# Altium schematic sheet POWER.SchDoc
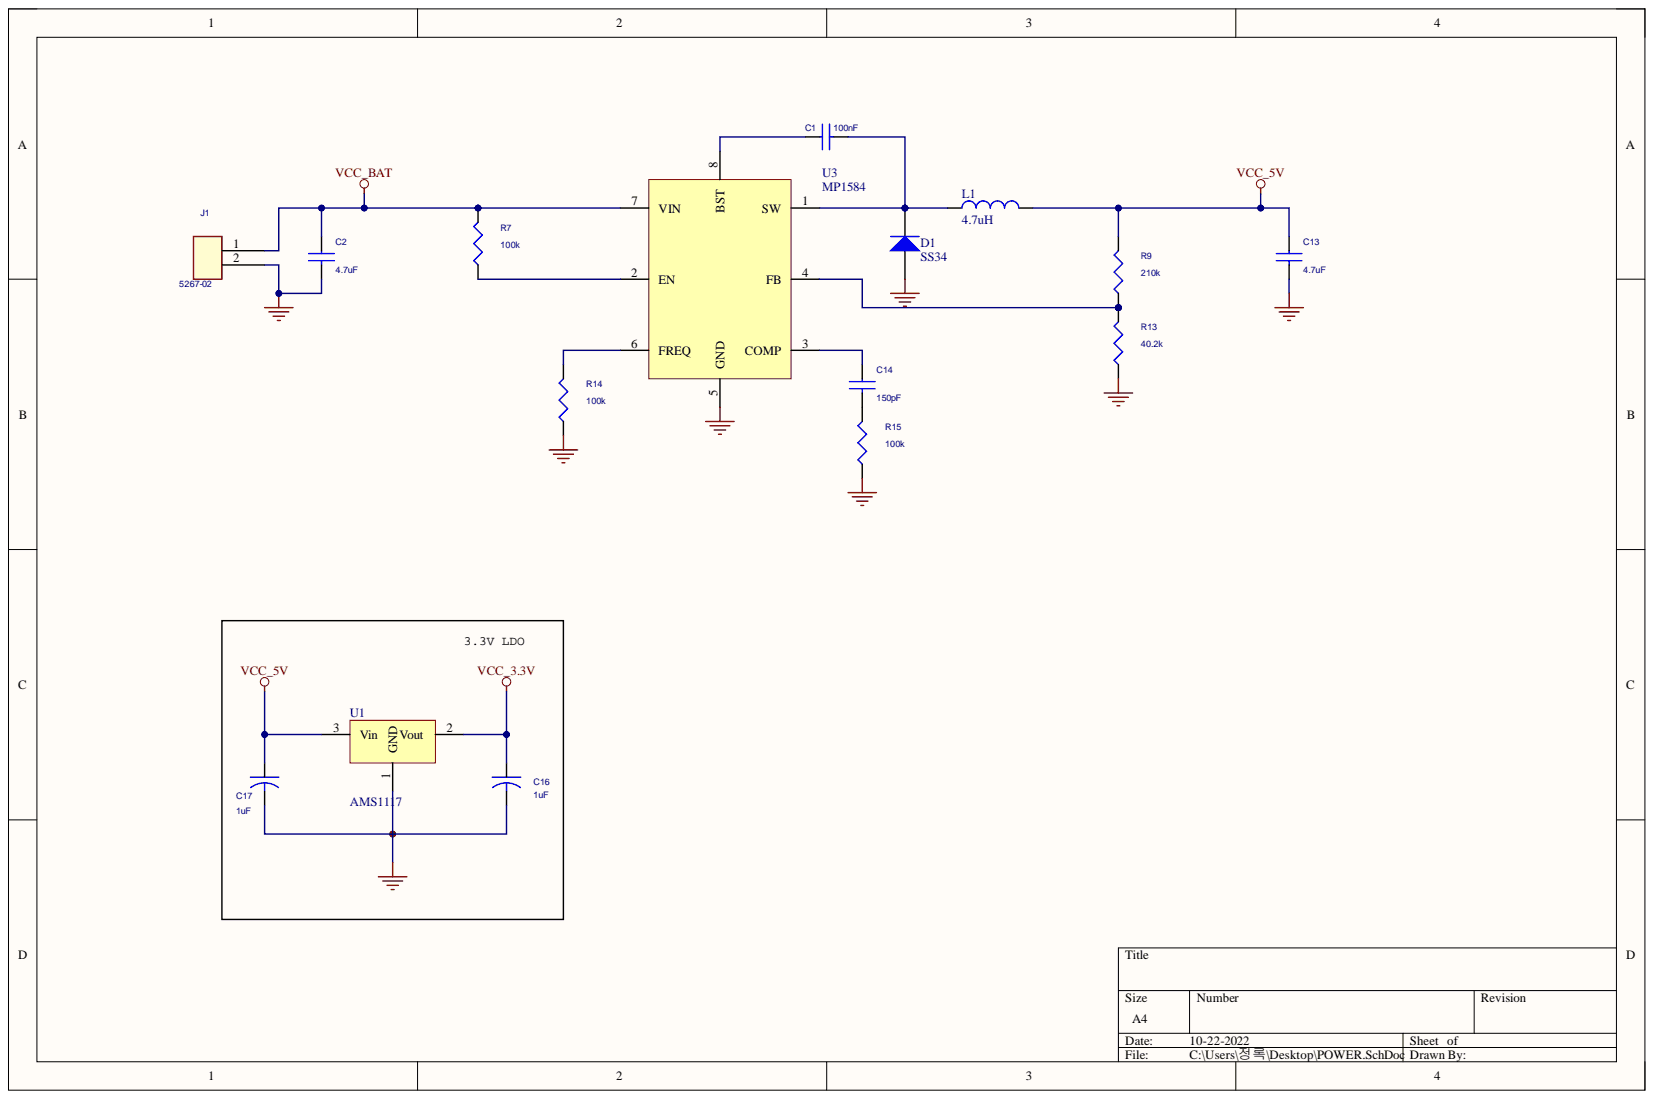
[diagram p0: POWER.SchDoc]

## Parts
C1  100nF  [C1608_CRABLED]
C2  4.7uF  [C1608_CRABLED]
C13  4.7uF  [C1608_CRABLED]
C14  150pF  [C1608_CRABLED]
C16  1uF  [DM_CC1608]
C17  1uF  [DM_CC1608]
D1  SS34  [SMA]
J1  5267-02  [5267-02]
L1  value 4.7uH  [CD73]  (hidden comment: Inductor)
R7  100k  [DM_CR1608]
R9  210k  [DM_CR1608]
R13  40.2k  [DM_CR1608]
R14  100k  [DM_CR1608]
R15  100k  [DM_CR1608]
U1  AMS1117  [SOT223_N]
U3  MP1584  [SO8_M]

## Nets
GND: C2.2 C13.2 C16.2 C17.2 D1.1 J1.2 R13.1 R14.1 R15.1 U1.1 U3.5(GND)
VCC_3.3V: C16.1 U1.2
VCC_5V: C13.1 C17.1 L1.2 R9.2 U1.3
VCC_BAT: C2.1 J1.1 R7.2 U3.7(VIN)
NetC1_1: C1.1 U3.8(BST)
NetC1_2: C1.2 D1.2 L1.1 U3.1(SW)
NetC14_1: C14.1 U3.3(COMP)
NetC14_2: C14.2 R15.2
NetR7_1: R7.1 U3.2(EN)
NetR9_1: R9.1 R13.2 U3.4(FB)
NetR14_2: R14.2 U3.6(FREQ)

## Library data of the parts
C1 C2 C13 C14 = CAP NP: Component Kind: Standard
C16 C17 = CAP: Component Kind: Standard
D1 = Diode: Default Diode; Code_JEDEC: DO-214-AB; Component Kind: Standard; LatestRevisionDate: 17-Jul-2002; LatestRevisionNote: Re-released for DXP Platform.; Library Name: Miscellaneous Devices.IntLib; PackageDescription: DO-214-AB/SMC; 2 C-Bend Leads; Body 7.9 x 5.9 mm, inc. leads (LxW); PackageReference: SMC; PackageVersion: Sep-1996; Published: 8-Jun-2000; Signal Integrity: Diode; Simulation: DIODE; Publisher: Altium Limited
J1 = CON2: Component Kind: Standard
L1 = Inductor: Code_IEC: 1005; Code_JEITA: 0402; Component Kind: Standard; Description: Inductor; LatestRevisionDate: 29-May-2009; LatestRevisionNote: IPC-7351 Footprint Added.; Library Name: Miscellaneous Devices.IntLib; PackageDescription: Chip Inductor; PackageReference: 0402-A; Published: 8-Jun-2000; Signal Integrity: Inductor; Simulation: INDUCTOR; Publisher: Altium Limited
R7 R9 R13-R15 = R: Component Kind: Standard
U1 = AMS1117: Component Kind: Standard; Library Name: JRLee.SchLib
U3 = MP1584: Component Kind: Standard; Library Name: JRLee.SchLib
